# Altium schematic sheet CASSA-ALIM.SchDoc(CASSA-ALIM)
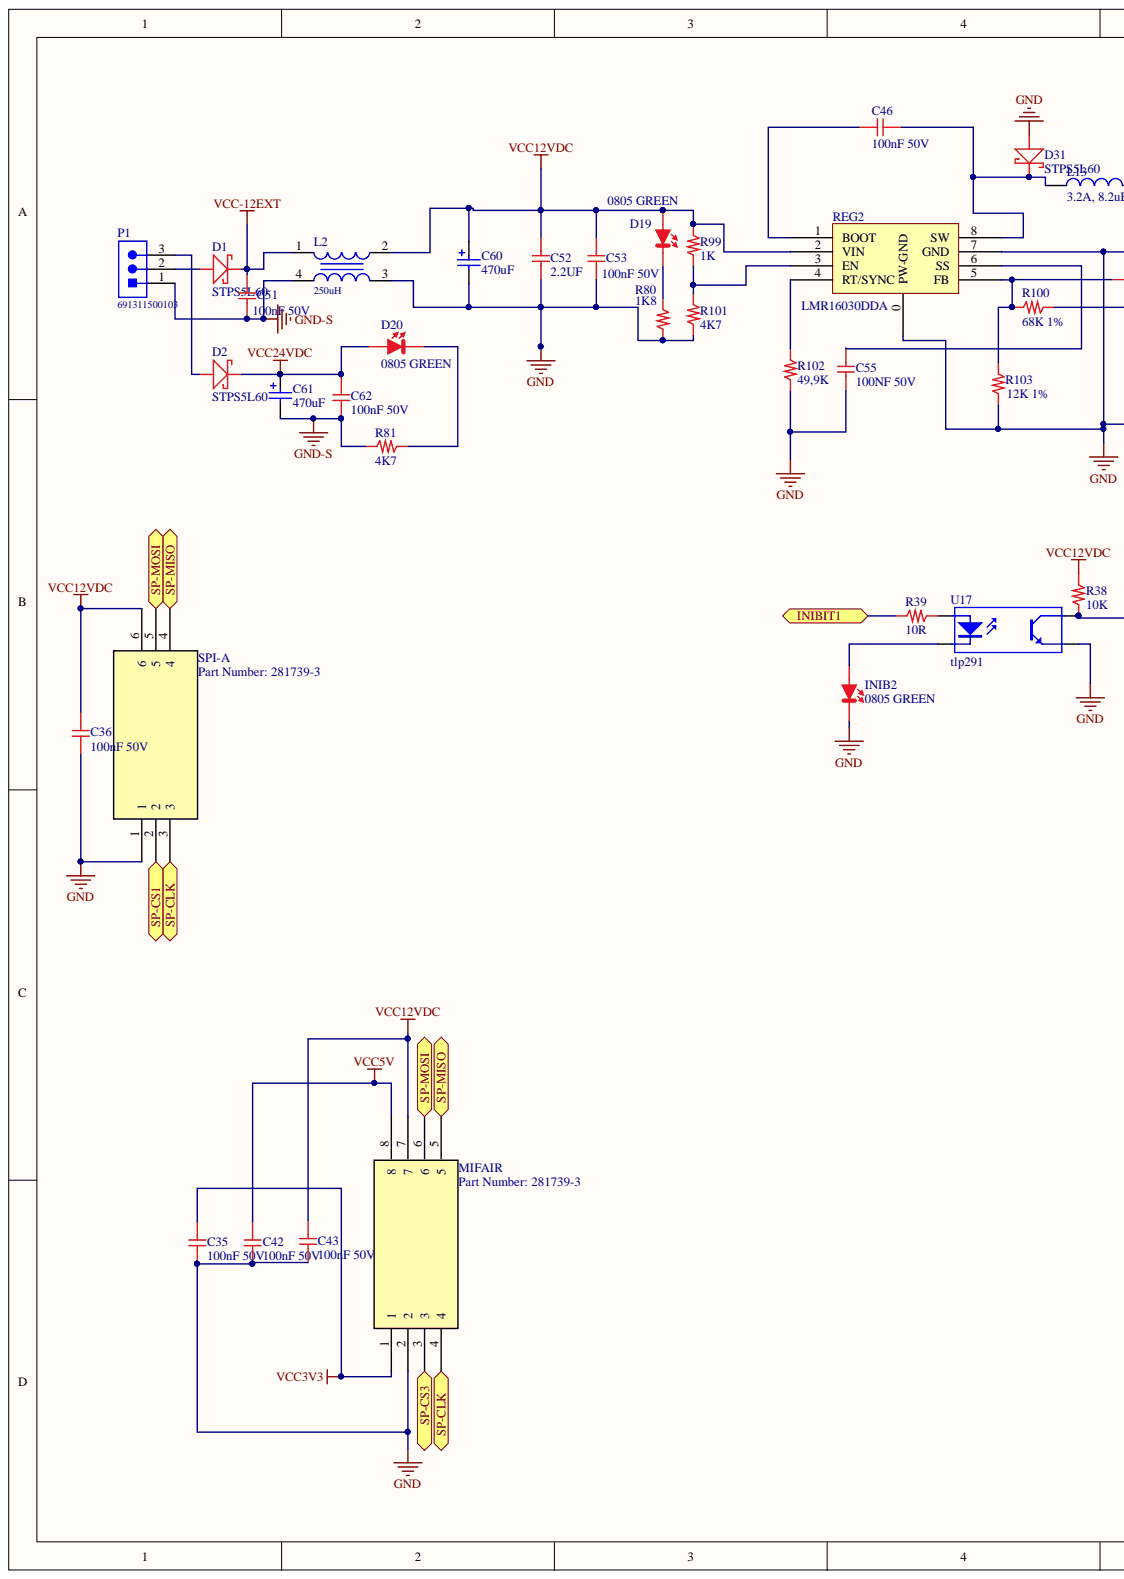
[diagram p0: CASSA-ALIM.SchDoc, left]
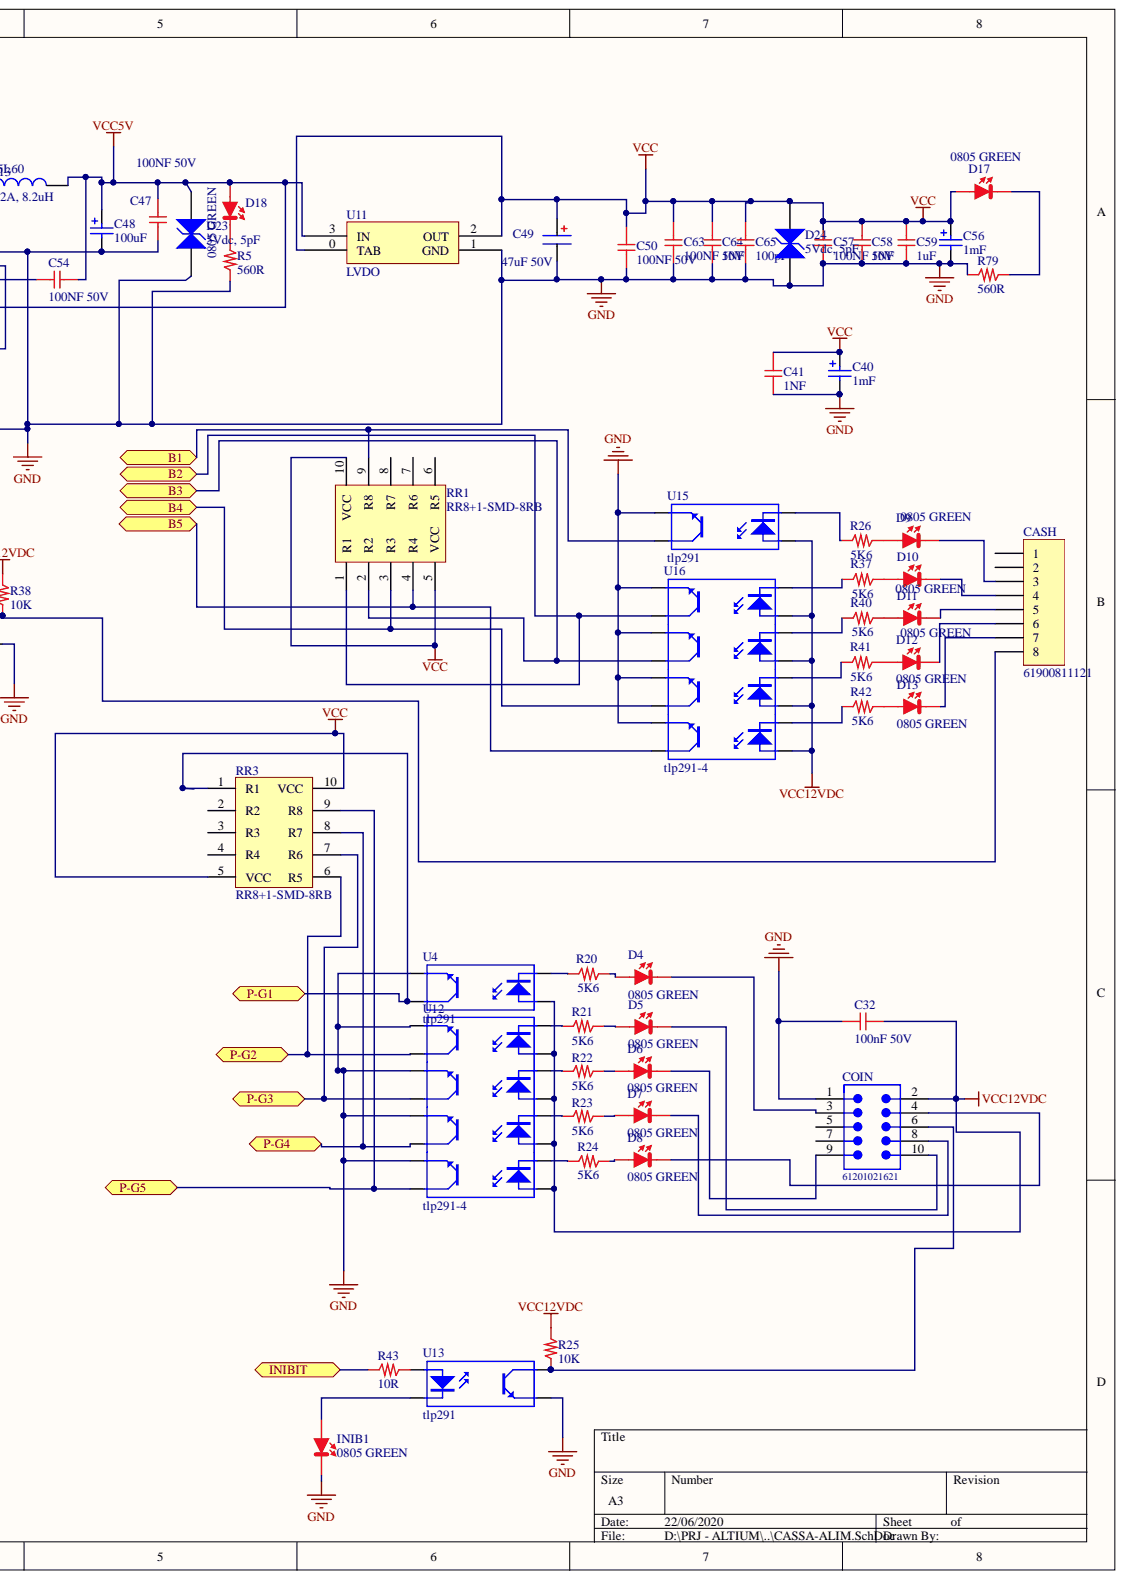
[diagram p1: CASSA-ALIM.SchDoc, right]

## Parts
C32  100nF 50V  [0603_c]
C35  100nF 50V  [0603_c]
C36  100nF 50V  [0603_c]
C40  value 1mF  [WCAP-ASLL_8x10.5]  (hidden comment: 865060153010)
C41  1NF  [0603_c]
C42  100nF 50V  [0603_c]
C43  100nF 50V  [0603_c]
C46  100nF 50V  [0603_c]
C47  100NF 50V  [0603_c]
C48  value 100uF  [WCAP-PSLC_10x12.4]  (hidden comment: 875075561005)
C49  47uF 50V  Tensione nominale: 50V  [6.3X5.8]
C50  100NF 50V  [0603_c]
C51  100nF 50V  [0603_c]
C52  2.2UF  [1206]
C53  100nF 50V  [0603_c]
C54  100NF 50V  [0603_c]
C55  100NF 50V  [0603_c]
C56  value 1mF  [WCAP-ASLL_8x10.5]  (hidden comment: 865060153010)
C57  100NF 50V  [0603_c]
C58  1NF  [0603_c]
C59  1uF  [0603_c]
C60  value 470uF  [WCAP-ASLL_16x17]  (hidden comment: 865060663013)
C61  value 470uF  [WCAP-ASLL_16x17]  (hidden comment: 865060663013)
C62  100nF 50V  [0603_c]
C63  100NF 50V  [0603_c]
C64  1NF  [0603_c]
C65  100pF  [0603_c]
CASH  61900811121  [61900811121]
COIN  61201021621  [61201021621]
D1  STPS5L60  [SMC]
D2  STPS5L60  [SMC]
D4  0805 GREEN  [0805_Red]
D5  0805 GREEN  [0805_Red]
D6  0805 GREEN  [0805_Red]
D7  0805 GREEN  [0805_Red]
D8  0805 GREEN  [0805_Red]
D9  0805 GREEN  [0805_Red]
D10  0805 GREEN  [0805_Red]
D11  0805 GREEN  [0805_Red]
D12  0805 GREEN  [0805_Red]
D13  0805 GREEN  [0805_Red]
D17  0805 GREEN  [0805_Red]
D18  0805 GREEN  [0805_Red]
D19  0805 GREEN  [0805_Red]
D20  0805 GREEN  [0805_Red]
D23  5Vdc, 5pF  [WE-VE_0603]
D24  5Vdc, 5pF  [WE-VE_0603]
D31  STPS5L60  [SMC]
INIB1  0805 GREEN  [0805_Red]
INIB2  0805 GREEN  [0805_Red]
L2  250uH  [WE-SL5_sectional]
L13  3.2A, 8.2uH  Inductance: 8.2uH  [WE-PD2_7850]
MIFAIR  Part Number: 281739-3  [AMP-HE14-8]
P1  691311500103  [691311500103]
R5  560R  [0603_R]
R20  5K6  [1206_R]
R21  5K6  [1206_R]
R22  5K6  [1206_R]
R23  5K6  [1206_R]
R24  5K6  [1206_R]
R25  10K  [0603_R]
R26  5K6  [1206_R]
R37  5K6  [1206_R]
R38  10K  [0603_R]
R39  10R  [0603_R]
R40  5K6  [1206_R]
R41  5K6  [1206_R]
R42  5K6  [1206_R]
R43  10R  [0603_R]
R79  560R  [0603_R]
R80  1K8  [1206_R]
R81  4K7  [2512_R]
R99  1K  [0603_R]
R100  68K 1%  [0603_R]
R101  4K7  [0603_R]
R102  49,9K  [0603_R]
R103  12K 1%  [0603_R]
REG2  LMR16030DDA  [SO-8-POW]
RR1  RR8+1-SMD-8RB  [RR8+1-SMD]
RR3  RR8+1-SMD-8RB  [RR8+1-SMD]
SPI-A  Part Number: 281739-3  [AMP-HE14]
U4  tlp291  [SO4_N]
U11  LVDO  [SOT-223]
U12  tlp291-4  [SO16_NO]
U13  tlp291  [SO4_N]
U15  tlp291  [SO4_N]
U16  tlp291-4  [SO16_NO]
U17  tlp291  [SO4_N]

## Ports
B1, B2, B3, B4, B5, INIBIT, INIBIT1, P-G1, P-G2, P-G3, P-G4, P-G5, SP-CLK, SP-CS1, SP-CS3, SP-MISO, SP-MOSI

## Nets
GND: C32.1 C35.2 C36.2 C40.2 C41.2 C42.2 C43.1 C47.2 C48.2 C49.2 C50.2 C52.2 C53.2 C55.2 C56.2 C57.2 C58.2 C59.2 C60.2 C63.2 C64.2 C65.2 COIN.1 D23.1 D24.1 D31.A INIB1.K INIB2.K L2.3 MIFAIR.2 R5.1 R79.1 R80.1 R101.1 R102.1 R103.1 REG2.0(PW-GND) REG2.7(GND) SPI-A.1 U4.3 U11.1(GND) U12.9 U12.11 U12.13 U12.15 U13.3 U15.3 U16.9 U16.11 U16.13 U16.15 U17.3
GND-S: C51.2 C61.2 C62.2 L2.4 P1.1 R81.1
VCC: C40.1 C41.1 C49.1 C50.1 C56.1 C57.1 C58.1 C59.1 C63.1 C64.1 C65.1 D17.A D24.2 RR1.5(VCC) RR1.10(VCC) RR3.5(VCC) RR3.10(VCC) U11.0(TAB) U11.2(OUT)
VCC3V3: C35.1 MIFAIR.1
VCC5V: C42.1 C47.1 C48.1 C54.1 D18.A D23.2 L13.2 MIFAIR.8 R100.1 U11.3(IN)
VCC12VDC: C32.2 C36.1 C43.2 C52.1 C53.1 C60.1 COIN.2 D19.A L2.2 MIFAIR.7 R25.1 R38.1 R99.2 REG2.2(VIN) SPI-A.6 U4.1 U12.1 U12.3 U12.5 U12.7 U15.1 U16.1 U16.3 U16.5 U16.7
VCC24VDC: C61.1 C62.1 D2.K D20.A
VCC-12EXT: C51.1 D1.K L2.1
B1: RR1.9(R8) U15.4 ; port B1
B2: RR1.1(R1) U16.10 ; port B2
B3: RR1.2(R2) U16.12 ; port B3
B4: RR1.3(R3) U16.14 ; port B4
B5: RR1.4(R4) U16.16 ; port B5
INIBIT: R43.1 ; port INIBIT
INIBIT1: R39.1 ; port INIBIT1
P-G1: RR3.1(R1) U4.4 ; port P-G1
P-G2: RR3.6(R5) U12.10 ; port P-G2
P-G3: RR3.7(R6) U12.12 ; port P-G3
P-G4: RR3.8(R7) U12.14 ; port P-G4
P-G5: RR3.9(R8) U12.16 ; port P-G5
SP-CLK: MIFAIR.4 SPI-A.3 ; port SP-CLK
SP-CS1: SPI-A.2 ; port SP-CS1
SP-CS3: MIFAIR.3 ; port SP-CS3
SP-MISO: MIFAIR.5 SPI-A.4 ; port SP-MISO
SP-MOSI: MIFAIR.6 SPI-A.5 ; port SP-MOSI
NetC46_1: C46.1 REG2.1(BOOT)
NetC46_2: C46.2 D31.K L13.1 REG2.8(SW)
NetC54_2: C54.2 R100.2 R103.2 REG2.5(FB)
NetC55_1: C55.1 REG2.6(SS)
NetCASH_3: CASH.3 D9.K
NetCASH_4: CASH.4 D10.K
NetCASH_5: CASH.5 D11.K
NetCASH_6: CASH.6 D12.K
NetCASH_7: CASH.7 D13.K
NetCASH_8: CASH.8 R38.2 U17.4
NetCOIN_3: COIN.3 D4.K
NetCOIN_4: COIN.4 D8.K
NetCOIN_6: COIN.6 R25.2 U13.4
NetCOIN_8: COIN.8 D7.K
NetCOIN_9: COIN.9 D6.K
NetCOIN_10: COIN.10 D5.K
NetD1_A: D1.A P1.2
NetD2_A: D2.A P1.3
NetD4_A: D4.A R20.2
NetD5_A: D5.A R21.2
NetD6_A: D6.A R22.2
NetD7_A: D7.A R23.2
NetD8_A: D8.A R24.2
NetD9_A: D9.A R26.2
NetD10_A: D10.A R37.2
NetD11_A: D11.A R40.2
NetD12_A: D12.A R41.2
NetD13_A: D13.A R42.2
NetD17_K: D17.K R79.2
NetD18_K: D18.K R5.2
NetD19_K: D19.K R80.2
NetD20_K: D20.K R81.2
NetINIB1_A: INIB1.A U13.2
NetINIB2_A: INIB2.A U17.2
NetR20_1: R20.1 U4.2
NetR21_1: R21.1 U12.8
NetR22_1: R22.1 U12.6
NetR23_1: R23.1 U12.4
NetR24_1: R24.1 U12.2
NetR26_1: R26.1 U15.2
NetR37_1: R37.1 U16.8
NetR39_2: R39.2 U17.1
NetR40_1: R40.1 U16.6
NetR41_1: R41.1 U16.4
NetR42_1: R42.1 U16.2
NetR43_2: R43.2 U13.1
NetR99_1: R99.1 R101.2 REG2.3(EN)
NetR102_2: R102.2 REG2.4(RT/SYNC)

Not connected: CASH 1 2; COIN 5 7; RR1 6(R5) 7(R6) 8(R7); RR3 2(R2) 3(R3) 4(R4)

## Library data of the parts
C32 C35 C36 C42 C43 C46 C47 C50 C51 C53-C55 C57 C62 C63 = 100NF 50V: Cap X7R 100nF 50V Flex Terminal; Produttore: Samsung; Cod. Produttore: CL10B104KB8SFNC; Cod. Interno: COCS50665050104; Library Name: CapSMD0603.SchLib; Component Kind: Standard; Cod. DigiKey: 1276-1936-2-ND
C40 C56 = 865060153010: WCAP-ASLL Aluminum Electrolytic Capacitors, size Ф8x10.5mm, 6.3V DC, 1mF; Rated Voltage: 6.3V DC; Size: Ф8x10.5mm; Published Date: June 2017; Part Number: 865060153010; Operating Temperature: -55 °C up to +105 °C; Life Cycle: 5000h; Library Name: WCAP-ASLL.SchLib; ComponentLink2URL: http://katalog.we-online.de/pbs/datasheet/865060153010.pdf; ComponentLink2Description: Datasheet; ComponentLink1URL: http://www.we-online.de; ComponentLink1Description: Manufacturer; Component Kind: Standard; Publisher: Wurth Elektronik
C41 C58 C64 = 1NF 50V: Cap X7R 1nF 50V; Cod. Interno: COCS 50665050102; Library Name: CapSMD0603.SchLib; Component Kind: Standard
C48 = 875075561005: WCAP-PSLC Aluminum Polymer Capacitors, size Ф10x12.4mm, 25V DC, 100uF; Rated Voltage: 25V DC; Size: Ф10x12.4mm; Published Date: June 2017; Part Number: 875075561005; Operating Temperature: -55 °C up to +105 °C; Life Cycle: 2000h; Library Name: WCAP-PSLC.SchLib; ComponentLink2URL: http://katalog.we-online.de/pbs/datasheet/875075561005.pdf; ComponentLink2Description: Datasheet; ComponentLink1URL: http://www.we-online.de; ComponentLink1Description: Manufacturer; Component Kind: Standard; Publisher: Wurth Elektronik
C49 = 10uF 50V: Produttore: PANASONIC; ESR: 0,12ohm; Durata: 10000 ORE; Cod. Produttore: EEHZA1H100R; Cod. Interno: ??; Capacità: 10uF; Temperature Range: 105°C; Component Kind: Standard; Cod. Farnell: 2095318; Case: C 5X6.5; Library Name: CapSMDElect.SchLib
C52 = 2.2UF 55V: Cap X5R 1UF 55V; Produttore: MURATA; Cod. Produttore: SCMD722FKR_21; Temperature Range: Da -55°C a +125°C; Library Name: CapSMD1206.SchLib; Component Kind: Standard
C59 = 1uF 10V: 2.2 µF, 10 V, ± 10%, X5R 0603; Produttore: WALSIN; Costo 1000: 0,0183; Costo 100: 0,0436; Cod. Produttore: 0603X225K100CT; Cod. Interno: COCS??; Library Name: CapSMD0603.SchLib; ComponentLink1URL: http://it.farnell.com/walsin/0603x225k100ct/cond-mlcc-x5r-2-2uf-10v-0603-bob/dp/2495422?st=2.2uf; ComponentLink1Description: FARNELL; Component Kind: Standard
C60 C61 = 865060663013: WCAP-ASLL Aluminum Electrolytic Capacitors, size Ф16x17mm, 50V DC, 470uF; Rated Voltage: 50V DC; Size: Ф16x17mm; Published Date: June 2017; Part Number: 865060663013; Operating Temperature: -55 °C up to +105 °C; Life Cycle: 5000h; Library Name: WCAP-ASLL.SchLib; ComponentLink2URL: http://katalog.we-online.de/pbs/datasheet/865060663013.pdf; ComponentLink2Description: Datasheet; ComponentLink1URL: http://www.we-online.de; ComponentLink1Description: Manufacturer; Component Kind: Standard; Publisher: Wurth Elektronik
C65 = 100pF 50V: Cap X7R 100pF 50V; Produttore: ??; Cod. Interno: COCS??; Library Name: CapSMD0603.SchLib; Component Kind: Standard; Cod. Produttore: ??
CASH = 61900811121: WR-WTB 2.54mm Male Locking Header, 8p; Pin Number: 8; Published Date: June 2017; Pitch: 2.54 mm; Part Number: 61900811121; ComponentLink2URL: http://katalog.we-online.de/em/datasheet/6190xx11121.pdf; ComponentLink2Description: Datasheet; ComponentLink1URL: http://www.we-online.de; ComponentLink1Description: Manufacturer; Component Kind: Standard; Publisher: Wurth Elektronik; Library Name: CONNETTORE-KK-2,54.SchLib
COIN = 61201021621: Male Box Header WR-BHD, THT, Vertical, pitch 2.54 mm, 10 pins; Working Voltage: 250 VAC; Withstanding Voltage: 500 VAC; Pitch: 2.54 mm; Pin Number: 10; Contact Resistance: 20 mOhm; Published Date: August 2018; Part Number: 61201021621; Packaging: Tray; Orientation: Vertical; Operating Temperature: -40 to 125 degC; Mounting Technology: THT; Gender: Male; ComponentLink2URL: https://katalog.we-online.de/em/datasheet/6120xx21621.pdf; ComponentLink2Description: Datasheet; ComponentLink1URL: http://www.we-online.de; ComponentLink1Description: Manufacturer; Component Kind: Standard; Publisher: Wurth Elektronik; Library Name: CONN-FLAT.SchLib
D1 D2 D31 = STPS5L60: Schottky 60V 3A; Vr: 40V; Vf @ 3A: 0.42V; Vf @ 1A: 0.35V; Produttore: Diodes; If(fsm): 80A; Costo 1000: 0.101; Costo 100: 0.168; Cod. Produttore: B320A-13-F; Cod. Interno: ??; If(av): 3A; Component Kind: Standard; Cod. Farnell: 1858605; Library Name: HSW.SchLib
D4-D13 D17-D20 INIB1 INIB2 = LED_ROSSO_0805: LED VERDE 0805; V: 2.0V; Produttore: WE; Luminosità: 150mcd; Cod. Produttore: 150080RS75000; Cod. Interno: LEDS 2AC080RS7500; Component Kind: Standard; Library Name: DiodiLED.SchLib
D23 D24 = 82356050050: WE-VE ESD Suppressor, size 0603, 5Vdc, 5pF; Leakage Current: 1uA; ESD Voltage air discharge: 15kV; Clamp Voltage: 75V; Working Voltage: 5Vdc; Size: 0603; Published Date: June 2019; Part Number: 82356050050; Packaging: Tape and Reel; Operating Temperature: -40 to +85degC; Mounting Technology: SMT; Library Name: WE-VE_0603.SchLib; ComponentLink2URL: https://katalog.we-online.com/pbs/datasheet/82356050050.pdf; ComponentLink2Description: Datasheet; ComponentLink1URL: http://www.we-online.de; ComponentLink1Description: Manufacturer; Component Kind: Standard; Capacitance: 5pF; Publisher: Wurth Elektronik
L2 = 744272251: WE-SL5 SMT Common Mode Line Filter, 250uH, 2A, 80V; Manufacturer: Wurth Elektronik; Winding Style: sectional; Leakage Inductance: 1500nH; Insulation Test Voltage: 1000VAC; Impedance max.: 970Ohm; DC Resistance max.: 0.035Ohm; Rated Voltage: 80V; Rated Current: 2A; Published Date: April 2020; Packaging: Tape and Reel; Operating Temperature: -40 to +125degC; Mounting Technology: SMT; Manufacturer Part Number: 744272251; Library Name: WE-SL5.SchLib; Inductance: 250uH; ComponentLink2URL: https://www.we-online.com/catalog/datasheet/744272251.pdf; ComponentLink2Description: Datasheet; ComponentLink1URL: http://www.we-online.de; ComponentLink1Description: Manufacturer; Component Kind: Standard
L13 = 744775082: WE-PD2 SMD Power Inductor, size 7850, 3.2A, 8.2uH; DC Resistance max.: 40mOhm; Size: 7850; Rated Current: 3.2A; Published Date: Feb. 2018; Part Number: 744775082; Operating Temperature: -40 to 125 degC; Mounting Technology: SMD; Library Name: WE-PD2_7850.SchLib; ComponentLink2URL: http://katalog.we-online.de/pbs/datasheet/744775082.pdf; ComponentLink2Description: Datasheet; ComponentLink1URL: http://www.we-online.de; ComponentLink1Description: Manufacturer; Component Kind: Standard; Publisher: Wurth Elektronik
MIFAIR = AMP-HE14_8: AMP-HE-14--8PIN; Vendor: TE Connectivity; Built_By: EMA_UL_Team; Value: Value; Type: DEV; RefDes: RefDes; Manufacturer_Part_Number: 281739-3; Component Kind: Standard; Library Name: HSW.SchLib; Copyright: Copyright (C) 2018 Accelerated Designs. All rights reserved
P1 = 691311500103: Serie 311 - 5.08mm Close Vertical PCB Header WR-TBL, 3 pin; Pin Number: 3; Gender: Male; Published Date: July 2018; Pitch: 5.08 mm; Part Number: 691311500103; Packaging: Box; Operating Temperature: -40 to 105 degC; Mounting Technology: THT; Library Name: Pluggable PCB System WR-TBL_5.08 mm.SchLib; ComponentLink2URL: http://katalog.we-online.de/em/datasheet/6913115001xx.pdf; ComponentLink2Description: Datasheet; ComponentLink1URL: http://www.we-online.de; ComponentLink1Description: Manufacturer; Component Kind: Standard; Publisher: Wurth Elektronik
R5 R79 = 560R: Res Film Spesso 1/16W 560R 5%; Cod. Interno: RESS 4166556B0561; Library Name: ResSMD0603.SchLib; Component Kind: Standard
R20-R24 R26 R37 R40-R42 = 2K2: 2K2 1206 1/4W; Library Name: ResSMD1206.SchLib; Component Kind: Standard; Case: 1206
R25 R38 = 10K: Res Film Spesso 1/16W 10K 5%; Produttore: ??; Cod. Interno: RESS4166556B0103??; Library Name: ResSMD0603.SchLib; Component Kind: Standard; Cod. Produttore: ??
R39 R43 = 10R: Res Film Spesso 1/16W 10R 5%; Produttore: ??; Cod. Interno: RESS4166556B0010??; Library Name: ResSMD0603.SchLib; Component Kind: Standard; Cod. Produttore: ??
R80 = 1K8: 1K8 1206 1/4W; Library Name: ResSMD1206.SchLib; Component Kind: Standard; Case: 1206
R81 = 4K7: Precision Thick Film 4K7 1W 1%; Produttore: Vishay Dale; Cod. Produttore: ERJ-1TNF54R9U; Cod. Interno: RESS 4190310A54R9; Library Name: ResSMD2512.SchLib; Component Kind: Standard; Cod. DigiKey: PT54.9AFTR-ND
R99-R103 = 2K7: Res Film Spesso 1/16W 2K7 5%; Produttore: VISHAY; Cod. Produttore: CRCW06032K70JNEA; Cod. Interno: RESS4166556B0272; Library Name: ResSMD0603.SchLib; Component Kind: Standard
SPI-A = AMP-HE14: AMP-HE-14--6PIN; Vendor: TE Connectivity; Built_By: EMA_UL_Team; Value: Value; Type: DEV; RefDes: RefDes; Manufacturer_Part_Number: 281739-3; Component Kind: Standard; Library Name: HSW.SchLib; Copyright: Copyright (C) 2018 Accelerated Designs. All rights reserved
U4 U13 U15 U17 = tlp291: 4-Pin Phototransistor Optocoupler; Published: 24-Mar-1999; PackageReference: DIP-4; LatestRevisionNote: Sch pins 3 & 4 Swapped.; LatestRevisionDate: 04-Mar-2005; DatasheetVersion: Nov-2003; Component Kind: Standard; Publisher: Altium Limited; Library Name: HSW.SchLib
U11 = LD1117S33TR: REGOLATORE IN; Component Kind: Standard; Library Name: HSW.SchLib
U12 U16 = tlp291_4: 4-Pin Phototransistor Optocoupler; Published: 24-Mar-1999; PackageReference: DIP-4; LatestRevisionNote: Sch pins 3 & 4 Swapped.; LatestRevisionDate: 04-Mar-2005; DatasheetVersion: Nov-2003; Component Kind: Standard; Publisher: Altium Limited; Library Name: HSW.SchLib
REG2 = LMR16030DDA: Library Name: 2019-02-07_18-39-28.SchLib; Component Kind: Standard
RR1 RR3 = RR8+1-SMD-8RB: Component Kind: Standard; Library Name: HSW.SchLib
